# Altium schematic sheet StepGenBufRev2b.SchDoc (continued)

## Library data of the parts
C20 C27 = Cap: CAP CER 10PF 50V C0G 0805; Manufacturer: KEMET; Manufacturer Part Number: C0805C100K5GACTU; Dielectric Material: Ceramic; Dissipation Factor: 0.1%; Tolerance: 10%; Case Code (Imperial): 0805; Case Code (Metric): 2012; Case/Package: 0805; Component Kind: Standard; Construction: Flat; Contact Plating: Tin,Matte; Depth: 1.25mm; Height: 0.78mm; Lead Free: Lead Free; Lead Pitch: 0.75mm; Length: 2mm; Library Name: Miscellaneous Devices.IntLib; Max Operating Temperature: 125°C; Min Operating Temperature: -55°C; Mount: Surface Mount; Package Quantity: 4000; Packaging: Tape and Reel; Radiation Hardening: No; REACH SVHC: No SVHC; RoHS Compliant: Yes; Series: C; Signal Integrity: Cap; Simulation: CAP; Supplier: Digi-Key; Supplier Part Number: 399-7975-1-ND; Termination: SMD/SMT; Thickness: 0.035inch; Voltage Rating: 50V; Voltage Rating (DC): 50V; Width: 0.049inch
  C20: Number of Pins: 2
  C27: Capacitance: 10pF; Value: 10pF
C21 C22 C24 C28 C32 C34 C38 C40 C44 C48 C52 = Cap: CAP CER 1UF 50V X7R 0805; Manufacturer: Samsung; Manufacturer Part Number: CL21B105KBFNNNE; Dielectric Material: Ceramic; Tolerance: 10%; Capacitance: 1uF; Case Code (Imperial): 0805; Case Code (Metric): 2012; Case/Package: 0805; Component Kind: Standard; Construction: Flat; Depth: 1.25mm; Height: 1.25mm; Length: 2mm; Library Name: Miscellaneous Devices.IntLib; Max Operating Temperature: 125°C; Min Operating Temperature: -55°C; Mount: Surface Mount; Number of Pins: 2; Package Quantity: 1; Packaging: Tape and Reel; Radiation Hardening: No; REACH SVHC: No SVHC; RoHS Compliant: Yes; Series: CL; Signal Integrity: Cap; Simulation: CAP; Supplier: Digi-Key; Supplier Part Number: 1276-1029-6-ND; Termination: SMD/SMT; Thickness: 0.053inch; Value: 1uF; Voltage Rating: 50V; Voltage Rating (DC): 50V; Width: 0.049inch
C23 C26 C30 C31 C36 C39 = Cap Pol1: CAP ALUM 100uF 20% 25V; Manufacturer: Rubycon; Manufacturer Part Number: 25YXJ100M5X11; Impedance: 400mR; Lead Diameter: 0.5mm; Leakage Current: 3uA; Life (Hours): 5000Hour; Polarity: Polar; Ripple Current: 250mA; Capacitance: 100uF; Case/Package: Radial; Category: Aluminum Electrolytic Capacitors; Component Kind: Standard; ComponentLink1Description: https://datasheet.ciiva.com/11777/0900766b810307a1-11777001.pdf?src-supplier=RSComponents; ComponentLink1URL: https://datasheet.ciiva.com/11777/0900766b810307a1-11777001.pdf?src-supplier=RSComponents; ComponentLink2Description: https://datasheet.ciiva.com/41806/e-yxj-41806041.pdf?src-supplier=Digi-Key; ComponentLink2URL: https://datasheet.ciiva.com/41806/e-yxj-41806041.pdf?src-supplier=Digi-Key; ComponentLink3Description: https://datasheet.ciiva.com/11690/e-yxj-11690904.pdf?src-supplier=Avnet; ComponentLink3URL: https://datasheet.ciiva.com/11690/e-yxj-11690904.pdf?src-supplier=Avnet; Construction: RADIAL; Diameter: 5mm; Height: 11mm; Height - Seated (Max): 0.492inch; Lead Pitch: 2mm; Library Name: Miscellaneous Devices.IntLib; Max Operating Temperature: 105°C; Min Operating Temperature: -40°C; Mount: Through Hole; Package Quantity: 3000; Packaging: Bulk; Pricing 1: 1=0.25000, 10=0.17200, 50=0.10360, 100=0.08740, 500=0.05980, 1000=0.05290, 2500=0.05060, 5000=0.04600 (USD); Radiation Hardening: No; RoHS: Yes; RoHS Compliant: Yes; Series: YXJ; Signal Integrity: Cap Pol; Simulation: CAP; Stock 1: 7789; Supplier: Digi-Key; Supplier Part Number 1: 1189-2237-ND; Termination: Radial; Tolerance: 20%; Value: 100uF; Voltage Rating: 25V
C25 C29 C33 C35 C37 C45 C47 C51 = Cap: CAP CER 10NF 100V X7R 0805; Manufacturer: Samsung; Manufacturer Part Number: CL21B103KCANNNC; Dielectric Material: Ceramic; Dissipation Factor: 2.5%; Tolerance: 10%; Capacitance: 10nF; Case Code (Imperial): 0805; Case Code (Metric): 2012; Case/Package: 0805; Component Kind: Standard; Construction: Flat; Contact Plating: Tin; Depth: 1.25mm; Height: 0.65mm; Length: 2mm; Library Name: Miscellaneous Devices.IntLib; Material: Ceramic; Max Operating Temperature: 125°C; Min Operating Temperature: -55°C; Mount: Surface Mount; Number of Pins: 2; Package Quantity: 1; Packaging: Tape and Reel; Radiation Hardening: No; REACH SVHC: No SVHC; RoHS Compliant: Yes; Series: CL; Signal Integrity: Cap; Simulation: CAP; Supplier: Digi-Key; Supplier Part Number: 1276-1249-6-ND; Termination: SMD/SMT; Thickness: 0.03inch; Value: 10nF; Voltage Rating: 100V; Voltage Rating (DC): 100V; Width: 0.049inch
C41 = Cap: CAP CER 1NF 250V X7R 0805; Manufacturer: KEMET; Manufacturer Part Number: C1206C102KAGECAUTO; Dialectric: COG; Capacitance: 1nF; Component Kind: Standard; Library Name: Miscellaneous Devices.IntLib; RoHS Compliant: Yes; Signal Integrity: Cap; Simulation: CAP; Supplier: Digi-Key; Supplier Part Number: 399-17177-2-ND; Value: 1nF; Voltage Rating: 250V
C42 = Cap: CAP CER 100PF 50V COG 0805; Manufacturer: KEMET; Manufacturer Part Number: C0805C101J5GACTU; Dielectric Material: Ceramic; Dissipation Factor: 0.1%; Temperature Coefficient: 30ppm/°C; Tolerance: 5%; Capacitance: 100pF; Case Code (Imperial): 0805; Case Code (Metric): 2012; Case/Package: 0805; Component Kind: Standard; Construction: Flat; Contact Material: Tin; Contact Plating: Tin,Matte; Depth: 1.25mm; Height: 0.78mm; Lead Free: Lead Free; Lead Pitch: 0.75mm; Length: 2mm; Library Name: Miscellaneous Devices.IntLib; Max Operating Temperature: 125°C; Min Operating Temperature: -55°C; Mount: Surface Mount,PCB; Number of Pins: 2; Package Quantity: 4000; Packaging: Tape and Reel; Radiation Hardening: No; REACH SVHC: No SVHC; RoHS Compliant: Yes; Series: C; Signal Integrity: Cap; Simulation: CAP; Supplier: Digi-Key; Supplier Part Number: 399-1122-6-ND; Termination: SMD/SMT; Thickness: 0.035inch; Value: 100pF; Voltage: 50V; Voltage Rating: 50V; Voltage Rating (DC): 50V; Width: 1.2mm
C43 C49 = Cap: CAP CER 0.1UF 50V X7R 0805; Manufacturer: KEMET; Manufacturer Part Number: C0805C104M5RACTU; Capacitance: 100nF; Dielectric Material: Ceramic; Dissipation Factor: 2.5%; Tolerance: 20%; Case Code (Imperial): 0805; Case Code (Metric): 2012; Case/Package: 0805; Component Kind: Standard; Construction: Flat; Depth: 1.25mm; Height: 0.9mm; Length: 2mm; Library Name: Miscellaneous Devices.IntLib; Max Operating Temperature: 125°C; Min Operating Temperature: -55°C; Mount: Surface Mount,PCB; Number of Pins: 2; Package Quantity: 1; Packaging: Tape and Reel; Radiation Hardening: No; REACH SVHC: No SVHC; Series: C; Signal Integrity: Cap; Simulation: CAP; Supplier: Digi-Key; Supplier Part Number: 399-1169-2-ND; Termination: SMD/SMT; Thickness: 0.035inch; Value: 0.1uF; Voltage Rating: 50V; Voltage Rating (DC): 50V; Weight: 0.000194oz; Width: 1.2mm
D20 D22 D24 = Dual-Si-Series-Separate: DIODE SI 85V 0.16mA SOT-23-3; Manufacturer: Nexperia; Manufacturer Part Number: BAV199,215; Component Kind: Standard; Library Name: MY_Diodes.SchLib; RoHS Compliant: Yes; Supplier: Digi-Key; Supplier Part Number: 1727-2909-6-ND; Value: BAV199
D21 D23 = Tranzorb: ESD Suppressor TVS ±30KV 2-Pin SMF; Manufacturer: Vishay Semiconductors; Manufacturer Part Number: SMF15A-E3-08; Breakdown Voltage: 16.7V; Clamping Voltage: 24.4V; Current Rating: 8.2A; Direction: Unidirectional; Element Configuration: Single; Max Power Dissipation: 200W; Number of Channels: 1; Operating Supply Voltage: 15V; Power Line Protection: No; Component Kind: Standard; Lead Free: Lead Free; Library Name: My_Miscellaneous Devices.SchLib; Max Operating Temperature: 150°C; Min Breakdown Voltage: 16.7V; Min Operating Temperature: -55°C; Mount: Surface Mount; Number of Pins: 2; Number of Unidirectional Channels: 1; Package Quantity: 3000; Packaging: Tape and Reel; Peak Pulse Current: 8.2A; Peak Pulse Power: 200W; Polarity: Unidirectional; Resistor Type: Zener; Reverse Standoff Voltage: 15V; RoHS Compliant: Yes; Supplier: Digi-Key; Supplier Part Number: SMF15A-E3-08DKR-ND; Termination: SMD/SMT; Working Voltage: 15V
Pot20 = POT-Single: Panel Control - 1/2" (12.5Mm) Sq 1-Turn / Tray Packaging Rohs Compliant: Yes; Manufacturer: Bourns; Manufacturer Part Number: 53CAD-E16-B20L; Actuator Diameter: 0.125inch; Actuator Length: 0.5inch; Max Power Dissipation: 500mW; Number of Gangs: 1; Resistance: 100kR; Temperature Coefficient: 1000ppm/°C; Tolerance: 20%; Category: Variable Resistors and Potentiometers; Component Kind: Standard; ComponentLink1Description: https://datasheet.ciiva.com/2153/50-2153467.pdf?src-supplier=Digi-Key; ComponentLink1URL: https://datasheet.ciiva.com/2153/50-2153467.pdf?src-supplier=Digi-Key; ComponentLink2Description: https://datasheet.ciiva.com/3266/50-3266891.pdf?src-supplier=Avnet; ComponentLink2URL: https://datasheet.ciiva.com/3266/50-3266891.pdf?src-supplier=Avnet; Contact Plating: Tin; Depth: 12.5mm; Length: 21.59mm; Max Operating Temperature: 125°C; Military Standard: Not; Min Operating Temperature: 1°C; Mount: Panel; Number of Turns: 1; Package Quantity: 45; Packaging: Bulk; Power Rating: 500mW; Pricing 1: 1=8.51000, 10=8.20800, 25=7.29600, 50=6.68800, 100=6.08000, 250=4.86400, 500=4.71200, 1000=4.40800, 5000=4.04320 (USD); Resistor Type: Conductive Plastic; RoHS: Yes; RoHS Compliant: Yes; Series: Pro Audio 53; Stock 1: 94; Supplier: Digi-Key; Supplier Part Number: 53CAD-E16-B20L-ND; Taper: Linear; Technology: CONDUCTIVE PLASTIC; Termination: Solder; Type: Potentiometer; Weight: 5.5g; Library Name: MY_POTS.SchLib
Q20 = NPN-2C: TRANS NPN 80V 1A SOT-223; Manufacturer: ON Semiconductor; Current - Collector Cutoff (Max): 100nA (ICBO); DC Current Gain (hFE) (Min) @ Ic, Vce: 100 @ 150mA, 2V; Frequency - Transition: 130MHz; Power - Max: 1.5W; Value: BCP56; Vce Saturation (Max) @ Ib, Ic: 500mV @ 50mA, 500mA; Category: Discrete Semiconductor Products; Component Kind: Standard; Current - Collector (Ic) (Max): 1A; Library Name: My_Miscellaneous Devices.SchLib; Mounting Type: Surface Mount; Operating Temperature: -65°C ~ 150°C (TJ); Package / Case: TO-261-4, TO-261AA; Packaging: Cut Tape (CT); Part Status: Active; RoHS: RoHS Compliant; Supplier: Digi-Key; Supplier Device Package: SOT-223; Supplier Part Number: BCP56-16T3GOSCT-ND; Transistor Type: NPN; Voltage - Collector Emitter Breakdown (Max): 80V
Q21 = PNP-2C: TRANS PNP 80V 1.5A SOT-223; Manufacturer: ON Semiconductor; Current - Collector Cutoff (Max): 100nA (ICBO); DC Current Gain (hFE) (Min) @ Ic, Vce: 100 @ 150mA, 2V; Frequency - Transition: 50MHz; Power - Max: 1.5W; Value: BCP53; Vce Saturation (Max) @ Ib, Ic: 500mV @ 50mA, 500mA; Category: Discrete Semiconductor Products; Component Kind: Standard; Current - Collector (Ic) (Max): 1.5A; Library Name: My_Miscellaneous Devices.SchLib; Mounting Type: Surface Mount; Operating Temperature: -65°C ~ 150°C (TJ); Package / Case: TO-261-4, TO-261AA; Packaging: Cut Tape (CT); Part Status: Active; RoHS: RoHS Compliant; Supplier: Digi-Key; Supplier Device Package: SOT-223; Supplier Part Number: BCP53-16T3GOSCT-ND; Transistor Type: PNP; Voltage - Collector Emitter Breakdown (Max): 80V
Q22 Q23 = 2N7000: Single N-Channel 250 V 14 Ohm 2.3 nC SIPMOS® Small Signal Mosfet - SOT-23; Manufacturer: Infineon; Continuous Drain Current (ID): 40mA; Drain to Source Voltage (Vdss): 250V; Max Power Dissipation: 360mW; Nominal Vgs: -1.4V; Number of Channels: 1; Rds On Max: 14R; Case/Package: SOT-23; Category: MOSFETs; Component Kind: Standard; ComponentLink1Description: http://www.farnell.com/datasheets/46048.pdf?src-supplier=Farnell; ComponentLink1URL: http://www.farnell.com/datasheets/46048.pdf?src-supplier=Farnell; ComponentLink2Description: https://www.distrelec.biz/Web/Downloads/ta/_e/dzBS139_Data_E.pdf?mime=application%2Fpdf&src-supplier=Distrelec; ComponentLink2URL: https://www.distrelec.biz/Web/Downloads/ta/_e/dzBS139_Data_E.pdf?mime=application%2Fpdf&src-supplier=Distrelec; ComponentLink3Description: https://produktinfo.conrad.com/datenblaetter/150000-174999/152941-da-01-en-TRANSISTOR_SMALL_SIGN_BSS139_SOT_23_INF.pdf?src-supplier=Conrad; ComponentLink3URL: https://produktinfo.conrad.com/datenblaetter/150000-174999/152941-da-01-en-TRANSISTOR_SMALL_SIGN_BSS139_SOT_23_INF.pdf?src-supplier=Conrad; Dual Supply Voltage: 250V; Halogen Free: Halogen Free; Library Name: Philips Discrete MOSFET - Low Power.IntLib; Manufacturer Part Number: BSS139; Max Dual Supply Voltage: 250V; Max Operating Temperature: 150°C; Min Operating Temperature: -55°C; Number of Pins: 3; Packaging: Tape and Reel; Power Dissipation: 360mW; Pricing 1: 1=0.60000, 10=0.51200, 100=0.38240, 500=0.30044, 1000=0.23215 (USD); REACH SVHC: No SVHC; RoHS: Yes; RoHS Compliant: Yes; Series: SIPMOS®; Simulation: 2N7000; Supplier: Digi-Key; Supplier Part Number: BSS139H6327XTSA1CT-ND; Termination: SMD/SMT; Threshold Voltage: -1.4V; Value: BSS139; Width: 3.05mm
R20 R25 R42 R43 R57 R63 = Res3: RES 49.9K OHM 1% 1/4W 0805; Manufacturer: KOA Speer; Manufacturer Part Number: RK73H2ATTD4992F; Power Rating: 125mW; Resistance: 49.9kR; Temperature Coefficient: 100ppm/°C; Voltage Rating: 150V; Case Code (Imperial): 0805; Case Code (Metric): 2012; Case/Package: 0805; Component Kind: Standard; Construction: Rectangular; Depth: 1.25mm; Height: 0.5mm; Lead Free: Lead Free; Length: 2mm; Library Name: Miscellaneous Devices.IntLib; Max Operating Temperature: 155°C; Military Standard: Not; Min Operating Temperature: -55°C; Mount: Surface Mount; Number of Pins: 2; Package Quantity: 5000; Packaging: Tape and Reel; Resistance Tolerance: 1%; Resistor Type: Thick Film; Series: RK73H; Signal Integrity: Res; Simulation: RESISTOR; Supplier: Digi-Key; Supplier Part Number: 2019-RK73H2ATTD4992FCT-ND; Technology: THICK FILM; Termination: SMD/SMT; Tolerance: 1%; Value: 49.9K; Voltage Rating (DC): 150V; Width: 1.25mm
  R57 R63: Category: Chip SMD Resistors; ComponentLink1Description: https://datasheet.ciiva.com/5554/rk73h-5554511.pdf?src-supplier=Mouser; ComponentLink1URL: https://datasheet.ciiva.com/5554/rk73h-5554511.pdf?src-supplier=Mouser; Pricing 1: 1=0.10000, 10=0.04200, 100=0.01710, 1000=0.00768, 2500=0.00666 (USD); RoHS: Yes
R21 = Res3: RES 90.9K OHM 1% 1/4W 0805; Manufacturer: KOA Speer; Manufacturer Part Number: RK73H2ATTD9092F; Power Rating: 125mW; Resistance: 90.9kR; Temperature Coefficient: 100ppm/°C; Voltage Rating: 150V; Case Code (Imperial): 0805; Case Code (Metric): 2012; Case/Package: 0805; Component Kind: Standard; Construction: Rectangular; Depth: 1.25mm; Height: 0.5mm; Lead Free: Lead Free; Length: 2mm; Library Name: Miscellaneous Devices.IntLib; Max Operating Temperature: 155°C; Military Standard: Not; Min Operating Temperature: -55°C; Number of Pins: 2; Package Quantity: 5000; Packaging: Tape and Reel; REACH SVHC: Unknown; Resistance Tolerance: 1%; Resistor Type: Thick Film; RoHS Compliant: Yes; Series: RK73H; Signal Integrity: Res; Simulation: RESISTOR; Supplier: Digi-Key; Supplier Part Number: 2019-RK73H2ATTD9092FCT-ND; Termination: SMD/SMT; Tolerance: 1%; Voltage Rating (DC): 150V; Width: 1.25mm
R22 R23 R26 R27 R31 R32 R58 R64 = Res3: RES 100K OHM 1% 1/4W 0805; Manufacturer: KOA Speer; Manufacturer Part Number: RK73H2ATTD1003F; Power Rating: 125mW; Resistance: 100kR; Temperature Coefficient: 100ppm/°C; Voltage Rating: 150V; Case Code (Imperial): 0805; Case Code (Metric): 2012; Case/Package: 0805; Component Kind: Standard; Construction: Rectangular; Depth: 1.25mm; Height: 0.5mm; Lead Free: Lead Free; Length: 2mm; Library Name: Miscellaneous Devices.IntLib; Max Operating Temperature: 155°C; Military Standard: Not; Min Operating Temperature: -55°C; Number of Pins: 2; Package Quantity: 5000; Packaging: Tape and Reel; REACH SVHC: Unknown; Resistance Tolerance: 1%; Resistor Type: Thick Film; RoHS Compliant: Yes; Series: RK73H; Signal Integrity: Res; Simulation: RESISTOR; Supplier: Digi-Key; Supplier Part Number: 2019-RK73H2ATTD1003FCT-ND; Termination: SMD/SMT; Tolerance: 1%; Value: 100K; Voltage Rating (DC): 150V; Width: 1.25mm
  R58 R64: Category: Chip SMD Resistors; ComponentLink1Description: https://datasheet.ciiva.com/7706/549017-7706108.pdf?src-supplier=Element14; ComponentLink1URL: https://datasheet.ciiva.com/7706/549017-7706108.pdf?src-supplier=Element14; ComponentLink2Description: https://datasheet.ciiva.com/15159/getdatasheetpartid-87891-15159863.pdf?src-supplier=Verical; ComponentLink2URL: https://datasheet.ciiva.com/15159/getdatasheetpartid-87891-15159863.pdf?src-supplier=Verical; Pricing 1: 1=0.10000, 10=0.04200, 100=0.01710, 1000=0.00768, 2500=0.00666 (USD); RoHS: Yes
R24 R47 = Res3: RES 100 OHM 1% 1/4W 0805; Manufacturer: KOA Speer; Manufacturer Part Number: RK73H2ATTD1000F; Max Power Dissipation: 250mW; Power Rating: 125mW; Resistance: 100R; Temperature Coefficient: 100ppm/°C; Voltage Rating: 150V; Case Code (Imperial): 0805; Case Code (Metric): 2012; Case/Package: 0805; Component Kind: Standard; Composition: Thick Film; Construction: Rectangular; Contact Plating: Matte,Tin; Depth: 1.25mm; Features: Automotive AEC-Q200; Height: 0.5mm; Lead Free: Lead Free; Length: 2mm; Library Name: Miscellaneous Devices.IntLib; Max Operating Temperature: 155°C; Military Standard: Not; Min Operating Temperature: -55°C; Mount: Surface Mount; Number of Pins: 2; Number of Terminations: 2; Package Quantity: 5000; Packaging: Tape and Reel; Radiation Hardening: No; REACH SVHC: Unknown; Resistance Tolerance: 1%; Resistor Type: Thick Film; RoHS Compliant: Yes; Series: RK73H; Signal Integrity: Res; Simulation: RESISTOR; Supplier: Digi-Key; Supplier Part Number: 2019-RK73H2ATTD1000FDKR-ND; Technology: THICK FILM; Termination: SMD/SMT; Tolerance: 1%; Value: 100; Voltage Rating (DC): 150V; Width: 1.25mm
R29 = Res3: RES 33.2K OHM 1% 1/4W 0805; Manufacturer: KOA Speer; Manufacturer Part Number: RK73H2ATTD3322F; Power Rating: 125mW; Resistance: 33.2kR; Temperature Coefficient: 100ppm/°C; Voltage Rating: 150V; Case Code (Imperial): 0805; Case Code (Metric): 2012; Case/Package: 0805; Category: Chip SMD Resistors; Component Kind: Standard; ComponentLink1Description: http://www.mouser.com/ds/2/219/RK73H-5715.pdf?src-supplier=Mouser; ComponentLink1URL: http://www.mouser.com/ds/2/219/RK73H-5715.pdf?src-supplier=Mouser; ComponentLink2Description: http://components.arrow.com/part/dataview/39728608S9852266N5146?src-supplier=Arrow+Electronics; ComponentLink2URL: http://components.arrow.com/part/dataview/39728608S9852266N5146?src-supplier=Arrow+Electronics; Height: 0.5mm; Lead Free: Lead Free; Length: 2mm; Library Name: Miscellaneous Devices.IntLib; Max Operating Temperature: 155°C; Min Operating Temperature: -55°C; Package Quantity: 5000; Packaging: Tape and Reel; Pricing 1: 1=0.10000, 10=0.04200, 100=0.01710, 1000=0.00768, 2500=0.00666 (USD); RoHS: Yes; Series: RK73H; Signal Integrity: Res; Simulation: RESISTOR; Stock 1: 1900; Supplier: Digi-Key; Supplier Part Number: 2019-RK73H2ATTD3322FCT-ND; Termination: SMD/SMT; Tolerance: 1%; Voltage Rating (DC): 150V; Width: 1.25mm
R30 = Res3: RES 3K OHM 1% 1/4W 0805; Manufacturer: KOA Speer; Manufacturer Part Number: RK73H2ATTD3001F; Max Power Dissipation: 250mW; Power Rating: 125mW; Resistance: 3kR; Temperature Coefficient: 100ppm/°C; Voltage Rating: 150V; Case Code (Imperial): 0805; Case Code (Metric): 2012; Case/Package: 0805; Component Kind: Standard; Composition: Thick Film; Construction: Rectangular; Contact Plating: Matte,Tin; Depth: 1.25mm; Features: Automotive AEC-Q200; Height: 0.5mm; Lead Free: Lead Free; Length: 2mm; Library Name: Miscellaneous Devices.IntLib; Max Operating Temperature: 155°C; Military Standard: Not; Min Operating Temperature: -55°C; Mount: Surface Mount; Number of Pins: 2; Number of Terminations: 2; Package Quantity: 5000; Packaging: Tape and Reel; Radiation Hardening: No; REACH SVHC: Unknown; Resistance Tolerance: 1%; Resistor Type: Thick Film; RoHS Compliant: Yes; Series: RK73H; Signal Integrity: Res; Simulation: RESISTOR; Supplier: Digi-Key; Supplier Part Number: 2019-RK73H2ATTD3001FCT-ND; Technology: THICK FILM; Termination: SMD/SMT; Tolerance: 1%; Value: 3K; Voltage Rating (DC): 150V; Width: 1.25mm
R34 = Res3: RES 41.2K OHM 1% 1/4W 0805; Manufacturer: KOA Speer; Manufacturer Part Number: RK73H2ATTD4122F; Power Rating: 125mW; Resistance: 41.2kR; Temperature Coefficient: 100ppm/°C; Voltage Rating: 150V; Case Code (Imperial): 0805; Case Code (Metric): 2012; Case/Package: 0805; Category: Chip SMD Resistors; Component Kind: Standard; ComponentLink1Description: http://www.koaspeer.com/catimages/Products/RK73H/RK73H.pdf?src-supplier=Mouser; ComponentLink1URL: http://www.koaspeer.com/catimages/Products/RK73H/RK73H.pdf?src-supplier=Mouser; Construction: Rectangular; Depth: 1.25mm; Height: 0.5mm; Lead Free: Lead Free; Length: 2mm; Library Name: Miscellaneous Devices.IntLib; Max Operating Temperature: 155°C; Military Standard: Not; Min Operating Temperature: -55°C; Package Quantity: 5000; Packaging: Tape and Reel; Pricing 1: 1=0.10000, 10=0.04200, 100=0.01710, 1000=0.00768, 2500=0.00666 (USD); REACH SVHC: Unknown; Resistance Tolerance: 1%; Resistor Type: Thick Film; RoHS: Yes; RoHS Compliant: Yes; Series: RK73H; Signal Integrity: Res; Simulation: RESISTOR; Supplier: Digi-Key; Supplier 1: Digi-Key; Supplier Part Number: 2019-RK73H2ATTD4122FCT-ND; Supplier Part Number 1: 2019-RK73H2ATTD4122FCT-ND; Termination: SMD/SMT; Tolerance: 1%; Voltage Rating (DC): 150V; Width: 1.25mm
R35 = Res3: RES 11K OHM 1% 1/4W 0805; Manufacturer: KOA Speer; Manufacturer Part Number: RK73H2ATTD1102F; Power Rating: 125mW; Resistance: 11kR; Temperature Coefficient: 100ppm/°C; Voltage Rating: 150V; Case Code (Imperial): 0805; Case Code (Metric): 2012; Case/Package: 0805; Component Kind: Standard; Construction: Rectangular; Depth: 1.25mm; Height: 0.5mm; Lead Free: Lead Free; Length: 2mm; Library Name: Miscellaneous Devices.IntLib; Max Operating Temperature: 155°C; Military Standard: Not; Min Operating Temperature: -55°C; Number of Pins: 2; Package Quantity: 5000; Packaging: Tape and Reel; REACH SVHC: Unknown; Resistance Tolerance: 1%; Resistor Type: Thick Film; Series: RK73H; Signal Integrity: Res; Simulation: RESISTOR; Supplier: Digi-Key; Supplier Part Number: 2019-RK73H2ATTD1102FCT-ND; Termination: SMD/SMT; Tolerance: 1%; Voltage Rating (DC): 150V; Width: 1.25mm
R36 = Res3: RES 10 OHM 1% 1/4W 0805; Manufacturer: KOA Speer; Manufacturer Part Number: RK73H2ATTD10R0F; Power Rating: 125mW; Resistance: 10R; Temperature Coefficient: 100ppm/°C; Voltage Rating: 150V; Case Code (Imperial): 0805; Case Code (Metric): 2012; Case/Package: 0805; Component Kind: Standard; Construction: Rectangular; Depth: 1.25mm; Height: 0.5mm; Lead Free: Lead Free; Length: 2mm; Library Name: Miscellaneous Devices.IntLib; Max Operating Temperature: 155°C; Military Standard: Not; Min Operating Temperature: -55°C; Number of Pins: 2; Package Quantity: 5000; Packaging: Tape and Reel; REACH SVHC: Unknown; Resistance Tolerance: 1%; Resistor Type: Thick Film; RoHS Compliant: Yes; Series: RK73H; Signal Integrity: Res; Simulation: RESISTOR; Supplier: Digi-Key; Supplier Part Number: 2019-RK73H2ATTD10R0FCT-ND; Termination: SMD/SMT; Tolerance: 1%; Voltage Rating (DC): 150V; Width: 1.25mm
R37 R41 = Res3: RES 100 OHM 1% 1/4W 0805; Manufacturer: KOA Speer; Manufacturer Part Number: RK73H2ATTD1000F; Power Rating: 125mW; Resistance: 100R; Temperature Coefficient: 100ppm/°C; Voltage Rating: 150V; Case Code (Imperial): 0805; Case Code (Metric): 2012; Case/Package: 0805; Component Kind: Standard; Construction: Rectangular; Depth: 1.25mm; Height: 0.5mm; Lead Free: Lead Free; Length: 2mm; Library Name: Miscellaneous Devices.IntLib; Max Operating Temperature: 155°C; Military Standard: Not; Min Operating Temperature: -55°C; Mount: Surface Mount; Number of Pins: 2; Package Quantity: 5000; Packaging: Tape and Reel; REACH SVHC: Unknown; Resistance Tolerance: 1%; Resistor Type: Thick Film; RoHS Compliant: Yes; Series: RK73H; Signal Integrity: Res; Simulation: RESISTOR; Supplier: Digi-Key; Supplier Part Number: 2019-RK73H2ATTD1000FCT-ND; Technology: THICK FILM; Termination: SMD/SMT; Tolerance: 1%; Value: 100; Voltage Rating (DC): 150V; Width: 1.25mm
R38 = Res3: RES 15K OHM 1% 1/4W 0805; Manufacturer: KOA Speer; Manufacturer Part Number: RK73H2ATTD1502F; Power Rating: 125mW; Resistance: 15kR; Temperature Coefficient: 100ppm/°C; Voltage Rating: 150V; Case Code (Imperial): 0805; Case Code (Metric): 2012; Case/Package: 0805; Component Kind: Standard; Construction: Rectangular; Depth: 1.25mm; Height: 0.5mm; Lead Free: Lead Free; Length: 2mm; Library Name: Miscellaneous Devices.IntLib; Max Operating Temperature: 155°C; Military Standard: Not; Min Operating Temperature: -55°C; Package Quantity: 5000; Packaging: Tape and Reel; REACH SVHC: Unknown; Resistance Tolerance: 1%; Resistor Type: Thick Film; RoHS Compliant: Yes; Series: RK73H; Signal Integrity: Res; Simulation: RESISTOR; Supplier: Digi-Key; Supplier Part Number: 2019-RK73H2ATTD1502FCT-ND; Termination: SMD/SMT; Tolerance: 1%; Voltage Rating (DC): 150V; Width: 1.25mm
R39 R40 R45 R55 = Res3: RES 10K OHM 1% 1/4W 0805; Manufacturer: KOA Speer; Manufacturer Part Number: RK73H2ATTD1002F; Power Rating: 125mW; Resistance: 10kR; Temperature Coefficient: 100ppm/°C; Voltage Rating: 150V; Case Code (Imperial): 0805; Case Code (Metric): 2012; Case/Package: 0805; Component Kind: Standard; Construction: Rectangular; Depth: 1.25mm; Height: 0.5mm; Lead Free: Lead Free; Length: 2mm; Library Name: Miscellaneous Devices.IntLib; Max Operating Temperature: 155°C; Military Standard: Not; Min Operating Temperature: -55°C; Number of Pins: 2; Package Quantity: 5000; Packaging: Tape and Reel; REACH SVHC: Unknown; Resistance Tolerance: 1%; Resistor Type: Thick Film; Series: RK73H; Signal Integrity: Res; Simulation: RESISTOR; Supplier: Digi-Key; Supplier Part Number: 2019-RK73H2ATTD1002FCT-ND; Termination: SMD/SMT; Tolerance: 1%; Voltage Rating (DC): 150V; Width: 1.25mm
  R39 R40: RoHS Compliant: Yes
  R45 R55: Category: Chip SMD Resistors; ComponentLink1Description: http://www.mouser.com/ds/2/219/RK73H-5715.pdf?src-supplier=Mouser; ComponentLink1URL: http://www.mouser.com/ds/2/219/RK73H-5715.pdf?src-supplier=Mouser; ComponentLink2Description: http://components.arrow.com/part/dataview/39728106S6972672N5146?src-supplier=Arrow+Electronics; ComponentLink2URL: http://components.arrow.com/part/dataview/39728106S6972672N5146?src-supplier=Arrow+Electronics; ComponentLink3Description: http://www.jameco.com/Jameco/Products/ProdDS/1889551.pdf?src-supplier=Jameco; ComponentLink3URL: http://www.jameco.com/Jameco/Products/ProdDS/1889551.pdf?src-supplier=Jameco; ComponentLink4Description: https://www.verical.com/server-webapp/html/getDatasheet?partID=91257&src-supplier=Verical; ComponentLink4URL: https://www.verical.com/server-webapp/html/getDatasheet?partID=91257&src-supplier=Verical; ComponentLink5Description: http://www.farnell.com/datasheets/549017.pdf?src-supplier=Element14; ComponentLink5URL: http://www.farnell.com/datasheets/549017.pdf?src-supplier=Element14; Pricing 1: 1=0.10000, 10=0.04200, 100=0.01710, 1000=0.00768, 2500=0.00666 (USD); RoHS: Yes; Value: 10K
R49 = Res3: RES 1K OHM 1% 1/4W 1206; Manufacturer: Stackpole Electronics; Manufacturer Part Number: RMCF1206FT1K00; Max Power Dissipation: 250mW; Resistance: 1kR; Temperature Coefficient: 100ppm/°C; Case Code (Imperial): 1206; Case Code (Metric): 3216; Case/Package: 1206; Component Kind: Standard; Construction: Rectangular; Contact Plating: Tin,Matte; Depth: 1.6mm; Features: Automotive AEC-Q200; Height: 0.028inch; Lead Free: Lead Free; Length: 3.2mm; Library Name: Miscellaneous Devices.IntLib; Max Operating Temperature: 155°C; Military Standard: Not; Min Operating Temperature: -55°C; Mount: Surface Mount; Number of Terminations: 2; Packaging: Tape and Reel; Power Rating: 250mW; Radiation Hardening: No; Resistor Type: Thick Film; RoHS Compliant: Yes; Series: RMCF; Signal Integrity: Res; Simulation: RESISTOR; Size Code: 1206; Supplier: Digi-Key; Supplier Part Number: RMCF1206FT1K00CT-ND; Tolerance: 1%; Value: 1K; Width: 0.063inch
R50 = Res3: RES 200 OHM 1% 1W 2512; Manufacturer: Bourns; Manufacturer Part Number: CRM2512-FX-2000ELF; Max Power Dissipation: 2W; Resistance: 200R; Temperature Coefficient: 100ppm/°C; Case/Package: 2512; Category: Chip SMD Resistors; Component Kind: Standard; ComponentLink1Description: http://www.farnell.com/datasheets/1931447.pdf?src-supplier=Farnell; ComponentLink1URL: http://www.farnell.com/datasheets/1931447.pdf?src-supplier=Farnell; ComponentLink2Description: http://www.bourns.com/docs/Product-Datasheets/CRM.pdf?src-supplier=Digi-Key; ComponentLink2URL: http://www.bourns.com/docs/Product-Datasheets/CRM.pdf?src-supplier=Digi-Key; ComponentLink3Description: http://www.farnell.com/datasheets/1931447.pdf?src-supplier=Element14; ComponentLink3URL: http://www.farnell.com/datasheets/1931447.pdf?src-supplier=Element14; Height: 0.031inch; Length: 0.248inch; Library Name: Miscellaneous Devices.IntLib; Number of Terminations: 2; Package Quantity: 4000; Packaging: Tape and Reel; Pricing 1: 1=0.63000, 10=0.44800, 100=0.23320, 1000=0.12558 (USD); Resistor Type: Thick Film; RoHS: Yes; RoHS Compliant: Yes; Signal Integrity: Res; Simulation: RESISTOR; Stock 1: 500; Supplier: Digi-Key; Supplier Part Number: CRM2512-FX-2000ELFCT-ND; Tolerance: 1%; Width: 0.122inch
R51 = Res3: RES 499 OHM 1% 1W 2512; Manufacturer: Stackpole Electronics; Manufacturer Part Number: RMCF2512FT499R; Resistance: 499R; Temperature Coefficient: 100ppm/°C; Case Code (Imperial): 2512; Case Code (Metric): 6432; Case/Package: 2512; Category: Chip SMD Resistors; Component Kind: Standard; ComponentLink1Description: https://www.seielect.com/catalog/SEI-RMCF_RMCP.pdf?src-supplier=Avnet; ComponentLink1URL: https://www.seielect.com/catalog/SEI-RMCF_RMCP.pdf?src-supplier=Avnet; ComponentLink2Description: https://www.seielect.com/catalog/sei-rmcf_rmcp.pdf?src-supplier=Digi-Key; ComponentLink2URL: https://www.seielect.com/catalog/sei-rmcf_rmcp.pdf?src-supplier=Digi-Key; ComponentLink3Description: http://components.arrow.com/part/dataview/50887089S161111N1174?src-supplier=Arrow+Electronics; ComponentLink3URL: http://components.arrow.com/part/dataview/50887089S161111N1174?src-supplier=Arrow+Electronics; ComponentLink4Description: https://www.seielect.com/catalog/SEI-Packaging.pdf?src-supplier=Digi-Key; ComponentLink4URL: https://www.seielect.com/catalog/SEI-Packaging.pdf?src-supplier=Digi-Key; ComponentLink5Description: http://www.seielect.com/Catalog/SEI-rmc.pdf?src-supplier=Future+Electronics; ComponentLink5URL: http://www.seielect.com/Catalog/SEI-rmc.pdf?src-supplier=Future+Electronics; Contact Plating: Tin,Matte; Features: Automotive AEC-Q200; Height: 0.028inch; Lead Free: Lead Free; Length: 0.248inch; Library Name: Miscellaneous Devices.IntLib; Max Operating Temperature: 155°C; Max Power Dissipation: 1W; Min Operating Temperature: -55°C; Mount: Surface Mount; Number of Terminations: 2; Packaging: Tape and Reel; Power Rating: 1W; Pricing 1: 1=0.37000, 10=0.31600, 100=0.12360, 1000=0.05175 (USD); Radiation Hardening: No; Resistor Type: Thick Film; RoHS: Yes; RoHS Compliant: Yes; Series: RMCF; Signal Integrity: Res; Simulation: RESISTOR; Size Code: 2512; Stock 1: 7089; Supplier: Digi-Key; Supplier Part Number: RMCF2512FT499RCT-ND; Tolerance: 1%; Value: 499; Width: 0.063inch
R52 = Res3: RES 1K OHM 1% 1W 2512; Manufacturer: Stackpole Electronics; Manufacturer Part Number: RMCF2512FT1K00; Resistance: 1kR; Temperature Coefficient: 100ppm/°C; Case Code (Imperial): 2512; Case Code (Metric): 6432; Case/Package: 2512; Category: Chip SMD Resistors; Component Kind: Standard; ComponentLink1Description: https://www.seielect.com/catalog/SEI-RMCF_RMCP.pdf?src-supplier=Avnet; ComponentLink1URL: https://www.seielect.com/catalog/SEI-RMCF_RMCP.pdf?src-supplier=Avnet; ComponentLink2Description: https://www.seielect.com/Catalog/SEI-RMCF_RMCP.pdf?src-supplier=Digi-Key; ComponentLink2URL: https://www.seielect.com/Catalog/SEI-RMCF_RMCP.pdf?src-supplier=Digi-Key; ComponentLink3Description: https://www.seielect.com/catalog/SEI-Packaging.pdf?src-supplier=Digi-Key; ComponentLink3URL: https://www.seielect.com/catalog/SEI-Packaging.pdf?src-supplier=Digi-Key; Contact Plating: Tin,Matte; Features: Automotive AEC-Q200; Height: 0.028inch; Lead Free: Lead Free; Length: 0.248inch; Library Name: Miscellaneous Devices.IntLib; Max Operating Temperature: 155°C; Max Power Dissipation: 1W; Min Operating Temperature: -55°C; Mount: Surface Mount; Number of Terminations: 2; Packaging: Tape and Reel; Power Rating: 1W; Pricing 1: 1=0.37000, 10=0.31600, 100=0.12360, 1000=0.05175 (USD); Radiation Hardening: No; Resistor Type: Thick Film; RoHS: Yes; RoHS Compliant: Yes; Series: RMCF; Signal Integrity: Res; Simulation: RESISTOR; Supplier: Digi-Key; Supplier Part Number: RMCF2512FT1K00CT-ND; Tolerance: 1%; Value: 1K; Width: 0.126inch
R53 = Res3: RES 2K OHM 1% 1/4W 0805; Manufacturer: KOA Speer; Manufacturer Part Number: RK73H2ATTD2001F; Power Rating: 125mW; Resistance: 2kR; Temperature Coefficient: 100ppm/°C; Voltage Rating: 150V; Case Code (Imperial): 0805; Case Code (Metric): 2012; Case/Package: 0805; Category: Chip SMD Resistors; Component Kind: Standard; ComponentLink1Description: http://components.arrow.com/part/dataview/39727829S9852233N5146?src-supplier=Arrow+Electronics; ComponentLink1URL: http://components.arrow.com/part/dataview/39727829S9852233N5146?src-supplier=Arrow+Electronics; ComponentLink2Description: http://www.mouser.com/ds/2/219/RK73H-5715.pdf?src-supplier=Mouser; ComponentLink2URL: http://www.mouser.com/ds/2/219/RK73H-5715.pdf?src-supplier=Mouser; ComponentLink3Description: http://www.jameco.com/Jameco/Products/ProdDS/1889913.pdf?src-supplier=Jameco; ComponentLink3URL: http://www.jameco.com/Jameco/Products/ProdDS/1889913.pdf?src-supplier=Jameco; ComponentLink4Description: http://www.farnell.com/datasheets/549017.pdf?src-supplier=Element14; ComponentLink4URL: http://www.farnell.com/datasheets/549017.pdf?src-supplier=Element14; Construction: Rectangular; Depth: 1.25mm; Height: 0.5mm; Lead Free: Lead Free; Length: 2mm; Library Name: Miscellaneous Devices.IntLib; Max Operating Temperature: 155°C; Military Standard: Not; Min Operating Temperature: -55°C; Mount: Surface Mount; Number of Pins: 2; Package Quantity: 5000; Packaging: Tape and Reel; Pricing 1: 1=0.10000, 10=0.04200, 100=0.01710, 1000=0.00768, 2500=0.00666 (USD); REACH SVHC: Unknown; Resistance Tolerance: 1%; Resistor Type: Thick Film; RoHS: Yes; RoHS Compliant: Yes; Series: RK73H; Signal Integrity: Res; Simulation: RESISTOR; Supplier: Digi-Key; Supplier Part Number: 2019-RK73H2ATTD2001FCT-ND; Technology: THICK FILM; Termination: SMD/SMT; Tolerance: 1%; Value: 2K; Voltage Rating (DC): 150V; Width: 1.25mm
R54 = Res3: RES 4.99K OHM 1% 1/4W 0805; Manufacturer: KOA Speer; Manufacturer Part Number: RK73H2ATTD4991F; Power Rating: 125mW; Resistance: 4.99kR; Temperature Coefficient: 100ppm/°C; Voltage Rating: 150V; Case Code (Imperial): 0805; Case Code (Metric): 2012; Case/Package: 0805; Category: Chip SMD Resistors; Component Kind: Standard; ComponentLink1Description: http://www.jameco.com/Jameco/Products/ProdDS/1890543.pdf?src-supplier=Jameco; ComponentLink1URL: http://www.jameco.com/Jameco/Products/ProdDS/1890543.pdf?src-supplier=Jameco; ComponentLink2Description: http://components.arrow.com/part/dataview/39727342S7171825N5146?src-supplier=Arrow+Electronics; ComponentLink2URL: http://components.arrow.com/part/dataview/39727342S7171825N5146?src-supplier=Arrow+Electronics; ComponentLink3Description: https://www.verical.com/server-webapp/html/getDatasheet?partID=72454&src-supplier=Verical; ComponentLink3URL: https://www.verical.com/server-webapp/html/getDatasheet?partID=72454&src-supplier=Verical; ComponentLink4Description: http://www.koaspeer.com/catimages/Products/RK73H/RK73H.pdf?src-supplier=Mouser; ComponentLink4URL: http://www.koaspeer.com/catimages/Products/RK73H/RK73H.pdf?src-supplier=Mouser; ComponentLink5Description: http://www.farnell.com/datasheets/549017.pdf?src-supplier=Element14; ComponentLink5URL: http://www.farnell.com/datasheets/549017.pdf?src-supplier=Element14; Construction: Rectangular; Depth: 1.25mm; Height: 0.5mm; Lead Free: Lead Free; Length: 2mm; Library Name: Miscellaneous Devices.IntLib; Max Operating Temperature: 155°C; Military Standard: Not; Min Operating Temperature: -55°C; Mount: Surface Mount; Number of Pins: 2; Package Quantity: 5000; Packaging: Tape and Reel; Pricing 1: 1=0.10000, 10=0.04200, 100=0.01710, 1000=0.00768, 2500=0.00666 (USD); Resistance Tolerance: 1%; Resistor Type: Thick Film; RoHS: Yes; RoHS Compliant: Yes; Series: RK73H; Signal Integrity: Res; Simulation: RESISTOR; Supplier: Digi-Key; Supplier Part Number: 2019-RK73H2ATTD4991FCT-ND; Technology: THICK FILM; Termination: SMD/SMT; Tolerance: 1%; Value: 4.99K; Voltage Rating (DC): 150V; Width: 1.25mm
R56 R62 = Res3: RES 20K OHM 1% 1/4W 0805; Manufacturer: KOA Speer; Manufacturer Part Number: RK73H2ATTD2002F; Power Rating: 125mW; Resistance: 20kR; Temperature Coefficient: 100ppm/°C; Voltage Rating: 150V; Case Code (Imperial): 0805; Case Code (Metric): 2012; Case/Package: 0805; Category: Chip SMD Resistors; Component Kind: Standard; ComponentLink1Description: https://datasheet.ciiva.com/26914/getdatasheetpartid-119202-26914629.pdf?src-supplier=Verical; ComponentLink1URL: https://datasheet.ciiva.com/26914/getdatasheetpartid-119202-26914629.pdf?src-supplier=Verical; ComponentLink2Description: https://datasheet.ciiva.com/5553/rk73h-5553870.pdf?src-supplier=Mouser; ComponentLink2URL: https://datasheet.ciiva.com/5553/rk73h-5553870.pdf?src-supplier=Mouser; Construction: Rectangular; Depth: 1.25mm; Height: 0.5mm; Lead Free: Lead Free; Length: 2mm; Library Name: Miscellaneous Devices.IntLib; Max Operating Temperature: 155°C; Military Standard: Not; Min Operating Temperature: -55°C; Package Quantity: 5000; Packaging: Tape and Reel; Pricing 1: 1=0.10000, 10=0.04200, 100=0.01710, 1000=0.00768, 2500=0.00666 (USD); REACH SVHC: Unknown; Resistance Tolerance: 1%; Resistor Type: Thick Film; RoHS: Yes; RoHS Compliant: Yes; Series: RK73H; Signal Integrity: Res; Simulation: RESISTOR; Supplier: Digi-Key; Supplier Part Number: 2019-RK73H2ATTD2002FCT-ND; Termination: SMD/SMT; Tolerance: 1%; Value: 20K; Voltage Rating (DC): 150V; Width: 1.25mm
R59 R65 = Res3: RES 200K OHM 1% 1/4W 0805; Manufacturer: KOA Speer; Manufacturer Part Number: RK73H2ATTD2003F; Power Rating: 125mW; Resistance: 200kR; Temperature Coefficient: 100ppm/°C; Voltage Rating: 150V; Case Code (Imperial): 0805; Case Code (Metric): 2012; Case/Package: 0805; Category: Chip SMD Resistors; Component Kind: Standard; ComponentLink1Description: https://datasheet.ciiva.com/5554/rk73h-5554702.pdf?src-supplier=Mouser; ComponentLink1URL: https://datasheet.ciiva.com/5554/rk73h-5554702.pdf?src-supplier=Mouser; ComponentLink2Description: https://datasheet.ciiva.com/7773/549017-7773398.pdf?src-supplier=Element14; ComponentLink2URL: https://datasheet.ciiva.com/7773/549017-7773398.pdf?src-supplier=Element14; Construction: Rectangular; Depth: 1.25mm; Height: 0.5mm; Lead Free: Lead Free; Length: 2mm; Library Name: Miscellaneous Devices.IntLib; Max Operating Temperature: 155°C; Military Standard: Not; Min Operating Temperature: -55°C; Mount: Surface Mount; Number of Pins: 2; Package Quantity: 5000; Packaging: Tape and Reel; Pricing 1: 1=0.10000, 10=0.04200, 100=0.01710, 1000=0.00768, 2500=0.00666 (USD); Resistance Tolerance: 1%; Resistor Type: Thick Film; RoHS: Yes; RoHS Compliant: Yes; Series: RK73H; Signal Integrity: Res; Simulation: RESISTOR; Stock 1: 14767; Supplier: Digi-Key; Supplier Part Number: 2019-RK73H2ATTD2003FCT-ND; Technology: THICK FILM; Termination: SMD/SMT; Tolerance: 1%; Value: 200K; Voltage Rating (DC): 150V; Width: 1.25mm
R60 = Res3: RES 499K OHM 1% 1/4W 0805; Manufacturer: KOA Speer; Manufacturer Part Number: RK73H2ATTD4993F; Power Rating: 125mW; Resistance: 499kR; Temperature Coefficient: 100ppm/°C; Voltage Rating: 150V; Case Code (Imperial): 0805; Case Code (Metric): 2012; Case/Package: 0805; Category: Chip SMD Resistors; Component Kind: Standard; ComponentLink1Description: https://datasheet.ciiva.com/5556/rk73h-5556664.pdf?src-supplier=Mouser; ComponentLink1URL: https://datasheet.ciiva.com/5556/rk73h-5556664.pdf?src-supplier=Mouser; Construction: Rectangular; Depth: 1.25mm; Height: 0.5mm; Lead Free: Lead Free; Length: 2mm; Library Name: Miscellaneous Devices.IntLib; Max Operating Temperature: 155°C; Military Standard: Not; Min Operating Temperature: -55°C; Package Quantity: 5000; Packaging: Tape and Reel; Pricing 1: 1=0.10000, 10=0.04200, 100=0.01710, 1000=0.00768, 2500=0.00666 (USD); Resistor Type: Thick Film; RoHS: Yes; RoHS Compliant: Yes; Series: RK73H; Signal Integrity: Res; Simulation: RESISTOR; Supplier: Digi-Key; Supplier Part Number: 2019-RK73H2ATTD4993FCT-ND; Termination: SMD/SMT; Tolerance: 1%; Value: 499K; Voltage Rating (DC): 150V; Width: 1.25mm
R61 = Res3: RES 1M OHM 1% 1/8W 0805; Manufacturer: KOA Speer; Manufacturer Part Number: RK73H2ATTD1004F; Power Rating: 125mW; Resistance: 1MR; Temperature Coefficient: 100ppm/°C; Voltage Rating: 150V; Case Code (Imperial): 0805; Case Code (Metric): 2012; Case/Package: 0805; Category: Chip SMD Resistors; Component Kind: Standard; ComponentLink1Description: https://datasheet.ciiva.com/5554/rk73h-5554472.pdf?src-supplier=Mouser; ComponentLink1URL: https://datasheet.ciiva.com/5554/rk73h-5554472.pdf?src-supplier=Mouser; ComponentLink2Description: https://datasheet.ciiva.com/7763/549017-7763157.pdf?src-supplier=Element14; ComponentLink2URL: https://datasheet.ciiva.com/7763/549017-7763157.pdf?src-supplier=Element14; Construction: Rectangular; Depth: 1.25mm; Height: 0.5mm; Lead Free: Lead Free; Length: 2mm; Library Name: Miscellaneous Devices.IntLib; Max Operating Temperature: 155°C; Military Standard: Not; Min Operating Temperature: -55°C; Number of Pins: 2; Package Quantity: 5000; Packaging: Tape and Reel; Pricing 1: 1=0.10000, 10=0.04200, 100=0.01710, 1000=0.00768, 2500=0.00666 (USD); REACH SVHC: Unknown; Resistance Tolerance: 1%; Resistor Type: Thick Film; RoHS: Yes; RoHS Compliant: Yes; Series: RK73H; Signal Integrity: Res; Simulation: RESISTOR; Supplier: Digi-Key; Supplier Part Number: 2019-RK73H2ATTD1004FCT-ND; Termination: SMD/SMT; Tolerance: 1%; Value: 1M; Voltage Rating (DC): 150V; Width: 1.25mm
S20 = SW-SPDT: Toggle Switch, 100 Series, SPDT, ON-OFF-ON, Sealed, 5A, 120VAC, 28VDC, PC Pin; Manufacturer: E-Switch; Manufacturer Part Number: 100SP3T1B1M2QEH; Actuator Color: Chrome; Actuator Length: 10.41mm; Actuator Material: Brass,Chrome; Actuator Type: Standard Round; Contact Resistance: 10mR; Electrical Life: 40000Cycles; Illumination Type: Non-Illuminated,Illuminated; Max Voltage Rating (AC): 250V; Max Voltage Rating (DC): 28V; Value: EG2377; Voltage Rating (AC): 120V; Category: Toggle Switches; Component Kind: Standard; ComponentLink1Description: Manufacturer Link; ComponentLink1URL: http://www.apem.com; ComponentLink2Description: https://datasheet.ciiva.com/2904/st113013b-2904808.pdf?src-supplier=Digi-Key; ComponentLink2URL: https://datasheet.ciiva.com/2904/st113013b-2904808.pdf?src-supplier=Digi-Key; ComponentLink3Description: https://datasheet.ciiva.com/31844/100-31844510.pdf?src-supplier=Onlinecomponents.com; ComponentLink3URL: https://datasheet.ciiva.com/31844/100-31844510.pdf?src-supplier=Onlinecomponents.com; ComponentLink4Description: https://datasheet.ciiva.com/16353/100-16353365.pdf?src-supplier=Future+Electronics; ComponentLink4URL: https://datasheet.ciiva.com/16353/100-16353365.pdf?src-supplier=Future+Electronics; Contact Material: Silver,Copper Alloy; Contact Plating: Silver; Current Rating: 5A; Housing Material: Stainless Steel; Lead Free: Lead Free; Length: 12.7mm; Library Name: Miscellaneous Devices.IntLib; Max Operating Temperature: 85°C; Min Operating Temperature: -30°C; Mount: Panel; Orientation: Straight; Package Quantity: 1; Packaging: Bulk; Pricing 1: 1=3.15000, 10=2.82700, 25=2.67120, 50=2.55380, 100=2.42350, 250=2.31924, 500=2.13684, 1000=2.03260 (USD); RoHS: Yes; RoHS Compliant: Yes; Series: 100; Stock 1: 6216; Supplier: Digi-Key; Supplier Part Number: EG2377-ND; Switch Function: On-Off-On; Termination: PC Pin; Throw Configuration: SPDT; Voltage Rating (DC): 28V; Width: 6.86mm
S21 = 16Way-SW: Rotary Switch; PC mount; 22.5° ; 1 deck; 1 pole/deck; 16 positions/pole; non-; Manufacturer: Grayhill; Manufacturer Part Number: 51P22-01-1-16N; Actuator Length: 9.53mm; Actuator Material: Stainless Steel; Contact Resistance: 10mR; Contact Style: Non-Shorting; Current Rating: 200mA; Illumination Type: Illuminated; Index Stops: Continuous; Max Voltage Rating (AC): 220V; Max Voltage Rating (DC): 28V; Number of Decks: 1; Number of Positions: 16; Throw Configuration: SP16T; Value: 51P22-01-1-16N; Voltage Rating (AC): 115V; Category: Rotary Switches; Component Kind: Standard; ComponentLink1Description: https://datasheet.ciiva.com/1632/718748-1632687.pdf?src-supplier=Farnell; ComponentLink1URL: https://datasheet.ciiva.com/1632/718748-1632687.pdf?src-supplier=Farnell; ComponentLink2Description: https://datasheet.ciiva.com/2887/rotary-50-51-2887768.pdf?src-supplier=Digi-Key; ComponentLink2URL: https://datasheet.ciiva.com/2887/rotary-50-51-2887768.pdf?src-supplier=Digi-Key; ComponentLink3Description: https://datasheet.ciiva.com/30262/14rotary-5051-30262927.pdf?src-supplier=Onlinecomponents.com; ComponentLink3URL: https://datasheet.ciiva.com/30262/14rotary-5051-30262927.pdf?src-supplier=Onlinecomponents.com; ComponentLink4Description: https://datasheet.ciiva.com/1531/718748-1531802.pdf?src-supplier=Element14; ComponentLink4URL: https://datasheet.ciiva.com/1531/718748-1531802.pdf?src-supplier=Element14; ComponentLink5Description: https://datasheet.ciiva.com/1532/718748-1532156.pdf?src-supplier=Element14; ComponentLink5URL: https://datasheet.ciiva.com/1532/718748-1532156.pdf?src-supplier=Element14; ComponentLink6Description: https://datasheet.ciiva.com/17407/rotary-50-51-17407109.pdf?src-supplier=Avnet+Europe; ComponentLink6URL: https://datasheet.ciiva.com/17407/rotary-50-51-17407109.pdf?src-supplier=Avnet+Europe; Contact Material: Metal; Contact Plating: Gold; Index Angle: 22.5°; Lead Free: Lead Free; Library Name: MY_SWITCH.SchLib; Mount: Through Hole,Panel; Number of Poles: 1; Number of Poles per Deck: 1; Orientation: Straight; Package Quantity: 1; Pricing 1: 1=27.06000, 5=23.18200, 10=21.72100, 25=19.94240, 50=18.67220, 100=17.72400 (USD); REACH SVHC: No SVHC; RoHS: Yes; RoHS Compliant: Yes; Series: 51; Stock 1: 379; Supplier: Digi-Key; Supplier Part Number: GH7687-ND; Termination: PC Pins; Voltage Rating (DC): 28V
S22 = 3PDT: SWITCH TOGGLE 3PDT 5A 120V; Manufacturer: E-Switch; Manufacturer Part Number: 1003P1T1B1M2QEH; Actuator Length: 10.41mm; Actuator Material: Brass,Chrome; Actuator Type: Standard Round; Electrical Life: 40000Cycles; Illumination Type: Non-Illuminated,Illuminated; Switch Function: On-On; Value: EG2425; Voltage Rating (DC): 28V; Component Kind: Standard; Contact Material: Copper,Silver; Contact Plating: Silver; Current Rating: 5A; Lead Free: Lead Free; Length: 12.7mm; Library Name: MY_SWITCH.SchLib; Max Operating Temperature: 85°C; Min Operating Temperature: -30°C; Mount: Panel; Orientation: Vertical; Package Quantity: 1; Packaging: Bulk; Series: 100; Supplier: Digi-Key; Supplier Part Number: EG2425-ND; Termination: PC Pin; Throw Configuration: 3PDT; Voltage Rating (AC): 120V; Width: 16.5mm
TP22 = TP-Round-Yellow: TP Thru-Hole; Manufacturer: UW; Manufacturer Part Number: Gold; Component Kind: Standard; Library Name: MY_TP.SchLib; Supplier: UW; Supplier Part Number: Gold; Value: TP Thru-Hole
TP25 = TP-Round-Black: TP Thru-Hole; Manufacturer: UW; Manufacturer Part Number: Gold; Component Kind: Standard; Library Name: MY_TP.SchLib; Supplier: UW; Supplier Part Number: Gold; Value: TP Thru-Hole
U20 = Dual OP Amp separte supplies: 40-V, dual 1MHz, rail-to-rail input/output, low-offset-voltage, low-power op amp 8-SOIC -40 to 125; Manufacturer: Texas Instruments; Manufacturer Part Number: OPA2990IDR; Category: Amplifiers - Op Amps, Buffer, Instrumentation; Component Kind: Standard; ComponentLink1Description: http://www.ti.com/general/docs/suppproductinfo.tsp?distId=10&amp;gotoUrl=http://www.ti.com/lit/gpn/OPA2990&src-supplier=Digi-Key; ComponentLink1URL: http://www.ti.com/general/docs/suppproductinfo.tsp?distId=10&amp;gotoUrl=http://www.ti.com/lit/gpn/OPA2990&src-supplier=Digi-Key; Library Name: My_OpAmps.SchLib; Pricing 1: 1=1.59000, 10=1.42700, 25=1.34640, 100=1.14700, 250=1.07696, 500=0.94234, 1000=0.88900 (USD); RoHS: Yes; Stock 1: 3095; Supplier: Digi-Key; Supplier Part Number: 296-53506-1-ND; Value: OPA2990
U21 = Single Op-Amp 5pin: Single, 40-V, 5-MHz, 4-mV offset voltage, 20-V/µs, In to V+ op amp with -40°C to 125°C operation 5-SOT-23 -40 to 125; Manufacturer: Texas Instruments; Manufacturer Part Number: TL071HIDBVR; Component Kind: Standard; ComponentLink1Description: https://octopart.com/opatz8j6/c1?t=KQKgit5w3kk38JCgrDyWdyceCGEFpBVzZwHj4ZWDBM3yphE1piAHR8V9zi53SQCteMhH7nMBDLFjn3M-xXb8X6oFaVT82ONc50sHF2723Y_V4GP3fED8Tnht8FhvyN3gVPnJnQHombiREa3DF6bTRxRI6W9pnOA5j9RE-JwvcxGXctKpErn2g4PKNhUI6GLW5qnuSJdiJ9IUB70vRBtPMU8Dsb32Rlnh8MQEgmS1eiGyUPTqECmtVDx5&src-supplier=Texas+Instruments; ComponentLink1URL: https://octopart.com/opatz8j6/c1?t=KQKgit5w3kk38JCgrDyWdyceCGEFpBVzZwHj4ZWDBM3yphE1piAHR8V9zi53SQCteMhH7nMBDLFjn3M-xXb8X6oFaVT82ONc50sHF2723Y_V4GP3fED8Tnht8FhvyN3gVPnJnQHombiREa3DF6bTRxRI6W9pnOA5j9RE-JwvcxGXctKpErn2g4PKNhUI6GLW5qnuSJdiJ9IUB70vRBtPMU8Dsb32Rlnh8MQEgmS1eiGyUPTqECmtVDx5&src-supplier=Texas+Instruments; ComponentLink2Description: https://sigma.octopart.com/155939316/technical_drawing/Texas-Instruments-TL071HIDBVR.pdf?src-supplier=Texas+Instruments; ComponentLink2URL: https://sigma.octopart.com/155939316/technical_drawing/Texas-Instruments-TL071HIDBVR.pdf?src-supplier=Texas+Instruments; Library Name: My_OpAmps.SchLib; Pricing 1: 1=0.45000, 10=0.36900, 25=0.33720, 100=0.25100, 250=0.22748, 500=0.18826, 1000=0.14119 (USD); Stock 1: 10508; Supplier: Digi-Key; Supplier Part Number: 296-TL071HIDBVRCT-ND; Value: TL071
U22 U23 = Single OP Amp separate supply.: IC OPAMP DIFF 18MHZ RRO 8SOIC; Manufacturer: Texas Instruments; Manufacturer Part Number: OPA209AIDR; Amplifier Type: DIFFERENTIAL; Common Mode Rejection Ratio: 120dB; Input Bias Current: 4.5nA; Input Offset Voltage (Vos): 150uV; Max Dual Supply Voltage: 18V; Max Frequency: 1kHz; Max Supply Voltage: 36V; Min Dual Supply Voltage: 2.25V; Min Supply Voltage: 4.5V; Nominal Supply Current: 2.5mA; Number of Amplifiers: 1; Operating Supply Current: 2.2mA; Output Current: 65mA; Power Supply Rejection Ratio (PSRR): 146.02dB; Slew Rate: 6.4V/µs; Voltage Gain: 132dB; Bandwidth: 18MHz; Case/Package: D; Component Kind: Standard; Contact Plating: Gold; Gain Bandwidth Product: 18MHz; Height: 1.3mm; Lead Free: Lead Free; Length: 3.05mm; Library Name: My_OpAmps.SchLib; Max I/O Voltage: 150uV; Max Operating Temperature: 125°C; Min Operating Temperature: -40°C; Nominal Gain Bandwidth Product: 18MHz; Number of Channels: 1; Number of Circuits: 1; Number of Elements: 1; Number of Pins: 8; Operating Supply Voltage: 18V; Output Current per Channel: 65mA; Package Quantity: 2500; Packaging: Tape and Reel; Radiation Hardening: No; REACH SVHC: No SVHC; RoHS Compliant: Yes; Series: -; Supplier: Digi-Key; Supplier Part Number: OPA209AIDR-ND; Supply Current: 2.5mA; Unity Gain Bandwidth Product: 18MHz; Value: OPA209A; Width: 1.75mm
